# Altium schematic sheet Power.SchDoc
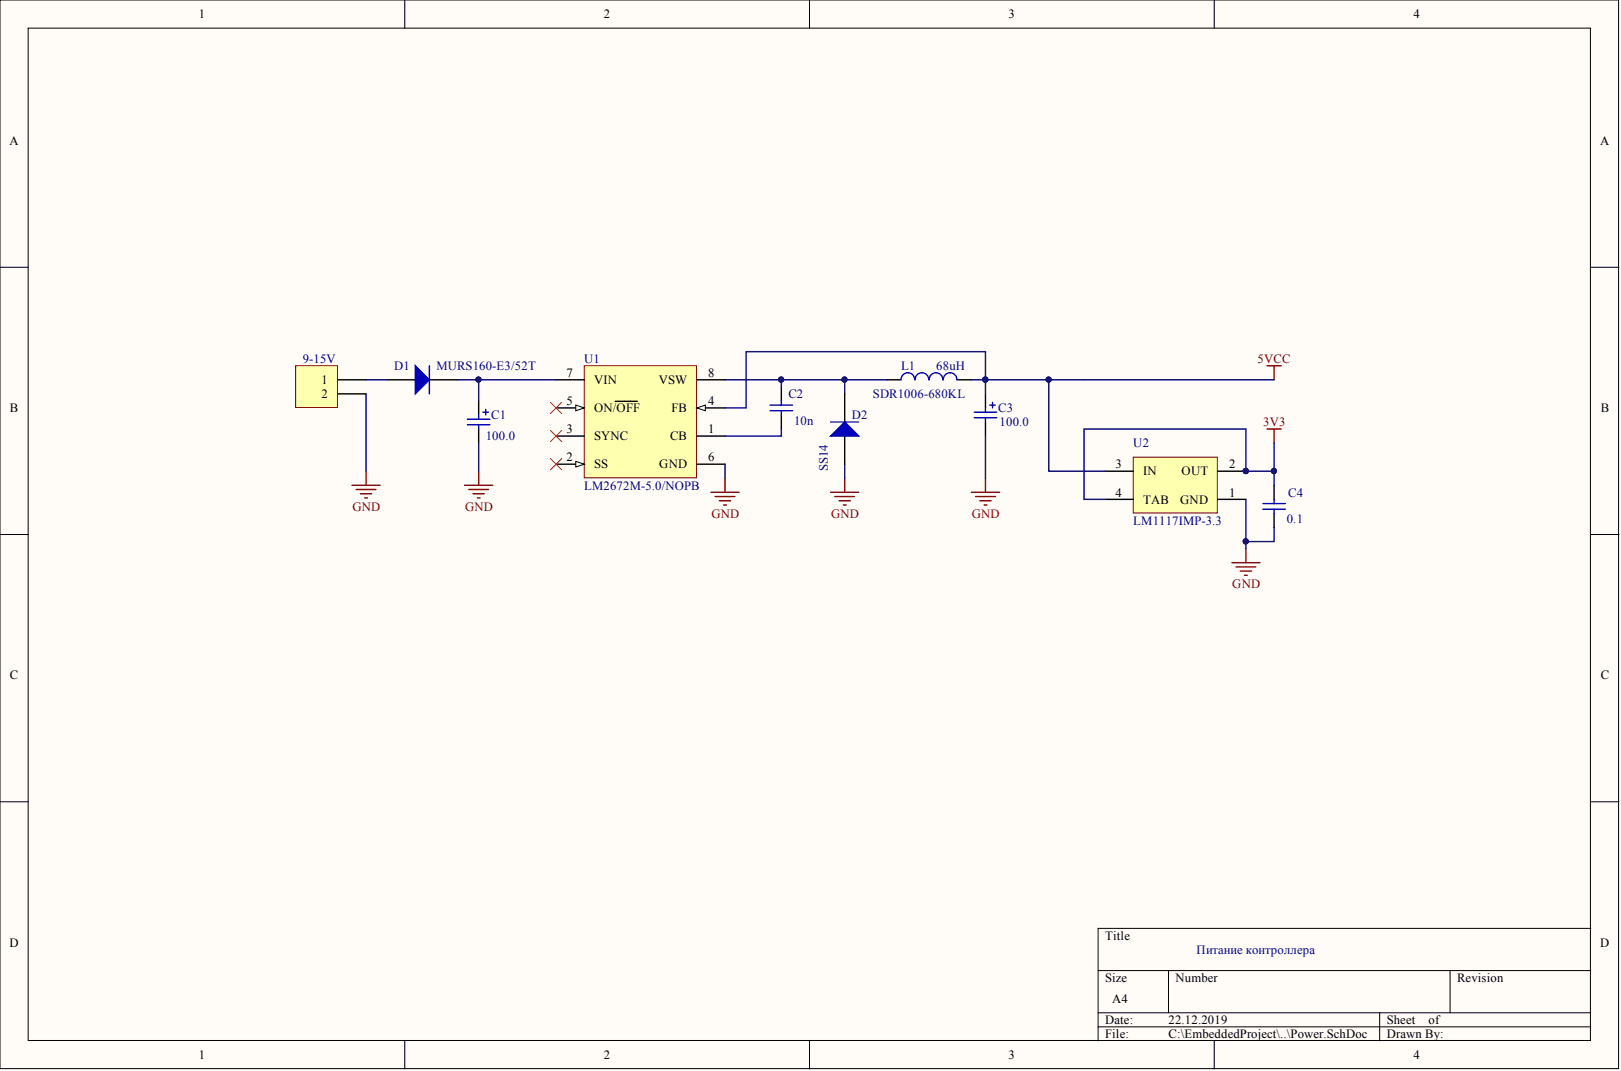
[diagram p0: Power.SchDoc]

## Parts
9-15V  Header 2  [308-021-14 (DG308-2.54-02P)]  (value not drawn)
C1  value 100.0  [EL SMD D8 h6.5]  (hidden comment: TECAP22/25VD10)
C2  value 10n  [C1206]  (hidden comment: Cap)
C3  value 100.0  [D]  (hidden comment: TECAP47/20VD10)
C4  value 0.1  [C1206]  (hidden comment: Cap)
D1  MURS160-E3/52T  [DO-214AA (SMB)]
D2  SS14  [DO-214AA (SMB)]
L1  SDR1006-680KL  value 68uH  [SDR1006]
U1  LM2672M-5.0/NOPB  [M08A_N]
U2  LM1117IMP-3.3  [MP04A_N]

## Nets
3V3: C4.2 U2.2(OUT) U2.4(TAB)
5VCC: C3.1 L1.2 U1.4(FB) U2.3(IN)
GND: 9-15V.2 C1.2 C3.2 C4.1 D2.1 U1.6(GND) U2.1(GND)
Net9-15V_1: 9-15V.1 D1.1
NetC1_1: C1.1 D1.2 U1.7(VIN)
NetC2_1: C2.1 D2.2 L1.1 U1.8(VSW)
NetC2_2: C2.2 U1.1(CB)

Not connected: U1 2(SS) 3(SYNC) 5(ON/OFF)

## Library data of the parts
9-15V = Header 2: Header, 2-Pin; Value: WF2; Component Kind: Standard; LatestRevisionDate: 17-Jul-2002; LatestRevisionNote: Re-released for DXP Platform.; Library Name: Miscellaneous Connectors.IntLib; Signal Integrity: Connector; Publisher: Altium Limited
C1 C3 = Cap Pol2: Polarized Capacitor (Axial); Component Kind: Standard; LatestRevisionDate: 17-Jul-2002; LatestRevisionNote: Re-released for DXP Platform.; Library Name: Miscellaneous Devices.IntLib; PackageDescription: Polarized Capacitor; 2 Leads; PackageReference: POLAR0.8; Published: 8-Jun-2000; Signal Integrity: Cap Pol; Simulation: CAP; Publisher: Altium Limited
C2 = Cap: Capacitor; Component Kind: Standard; LatestRevisionDate: 17-Jul-2002; LatestRevisionNote: Re-released for DXP Platform.; Library Name: Miscellaneous Devices.IntLib; PackageReference: RAD-0.3; Published: 8-Jun-2000; Signal Integrity: Cap; Simulation: CAP; Publisher: Altium Limited
C4 = Cap: Capacitor; PartNumber: GRM31CR72A104K; DeliveryTime: 7; Component Kind: Standard; Library Name: Miscellaneous Devices.IntLib; Price: 10.00; Signal Integrity: Cap; Simulation: CAP
D1 D2 = Diode: Default Diode; Code_JEDEC: DO-214-AB; Component Kind: Standard; LatestRevisionDate: 17-Jul-2002; LatestRevisionNote: Re-released for DXP Platform.; Library Name: Miscellaneous Devices.IntLib; PackageDescription: DO-214-AB/SMC; 2 C-Bend Leads; Body 7.9 x 5.9 mm, inc. leads (LxW); PackageReference: SMC; PackageVersion: Sep-1996; Published: 8-Jun-2000; Signal Integrity: Diode; Simulation: DIODE; Publisher: Altium Limited
L1 = Inductor: Art: B82472G6683M000; Code_IEC: 1005; Code_JEITA: 0402; Component Kind: Standard; Description: Inductor; LatestRevisionDate: 29-May-2009; LatestRevisionNote: IPC-7351 Footprint Added.; Library Name: Miscellaneous Devices.IntLib; PackageDescription: Chip Inductor; PackageReference: 0402-A; Published: 8-Jun-2000; Signal Integrity: Inductor; Simulation: INDUCTOR; Publisher: Altium Limited
U1 = LM2672M-5.0/NOPB: SIMPLE SWITCHER® Power Converter High Efficiency 1A Step-Down Voltage Regulator with Features, 8-pin Narrow SOIC, Pb-Free; Manufacturer: National Semiconductor; High-Reliability: Y; PackageTopMarking: NSZXTT*2672*M5.0; PowerWise: N; Code_IPC: SOIC127P600-8; Code_JEDEC: MS-012-AA; Component Kind: Standard; ComponentLink1Description: Manufacturer URL; ComponentLink1URL: http://www.national.com/; ComponentLink2Description: LM2672 Datasheet; ComponentLink2URL: http://www.national.com/ds/LM/LM2672.pdf; ComponentLink3Description: LM2672 Web Page; ComponentLink3URL: http://www.national.com/pf/LM/LM2672.html; ComponentLink4Description: M08A Package Page; ComponentLink4URL: http://www.national.com/packaging/folders/m08a.html; CompType: Switcher; DatasheetVersion: Apr-2007; Library Name: National Semiconductor Switcher.IntLib; PackageDescription: SOIC Narrow, 4.9 x 3.9 x 1.45mm, 8 Lead, 1.27mm Pitch; PackageReference: M08A; PackageVersion: revM, May-2009; PartNumber: LM2672M-5.0/NOPB; PinCount: 8; RoHS: Y
U2 = LM1117IMP-3.3: 800mA Low-Dropout Linear Regulator, 4-pin SOT-223; PartNumber: LM1117IMP-3.3/NOPB; DeliveryTime: 7; Component Kind: Standard; Library Name: National Semiconductor LDO.IntLib; Price: 43.00; Value: LM1117IMP-3.3
